# Altium schematic sheet 1.2.0_XBee_module.SchDoc
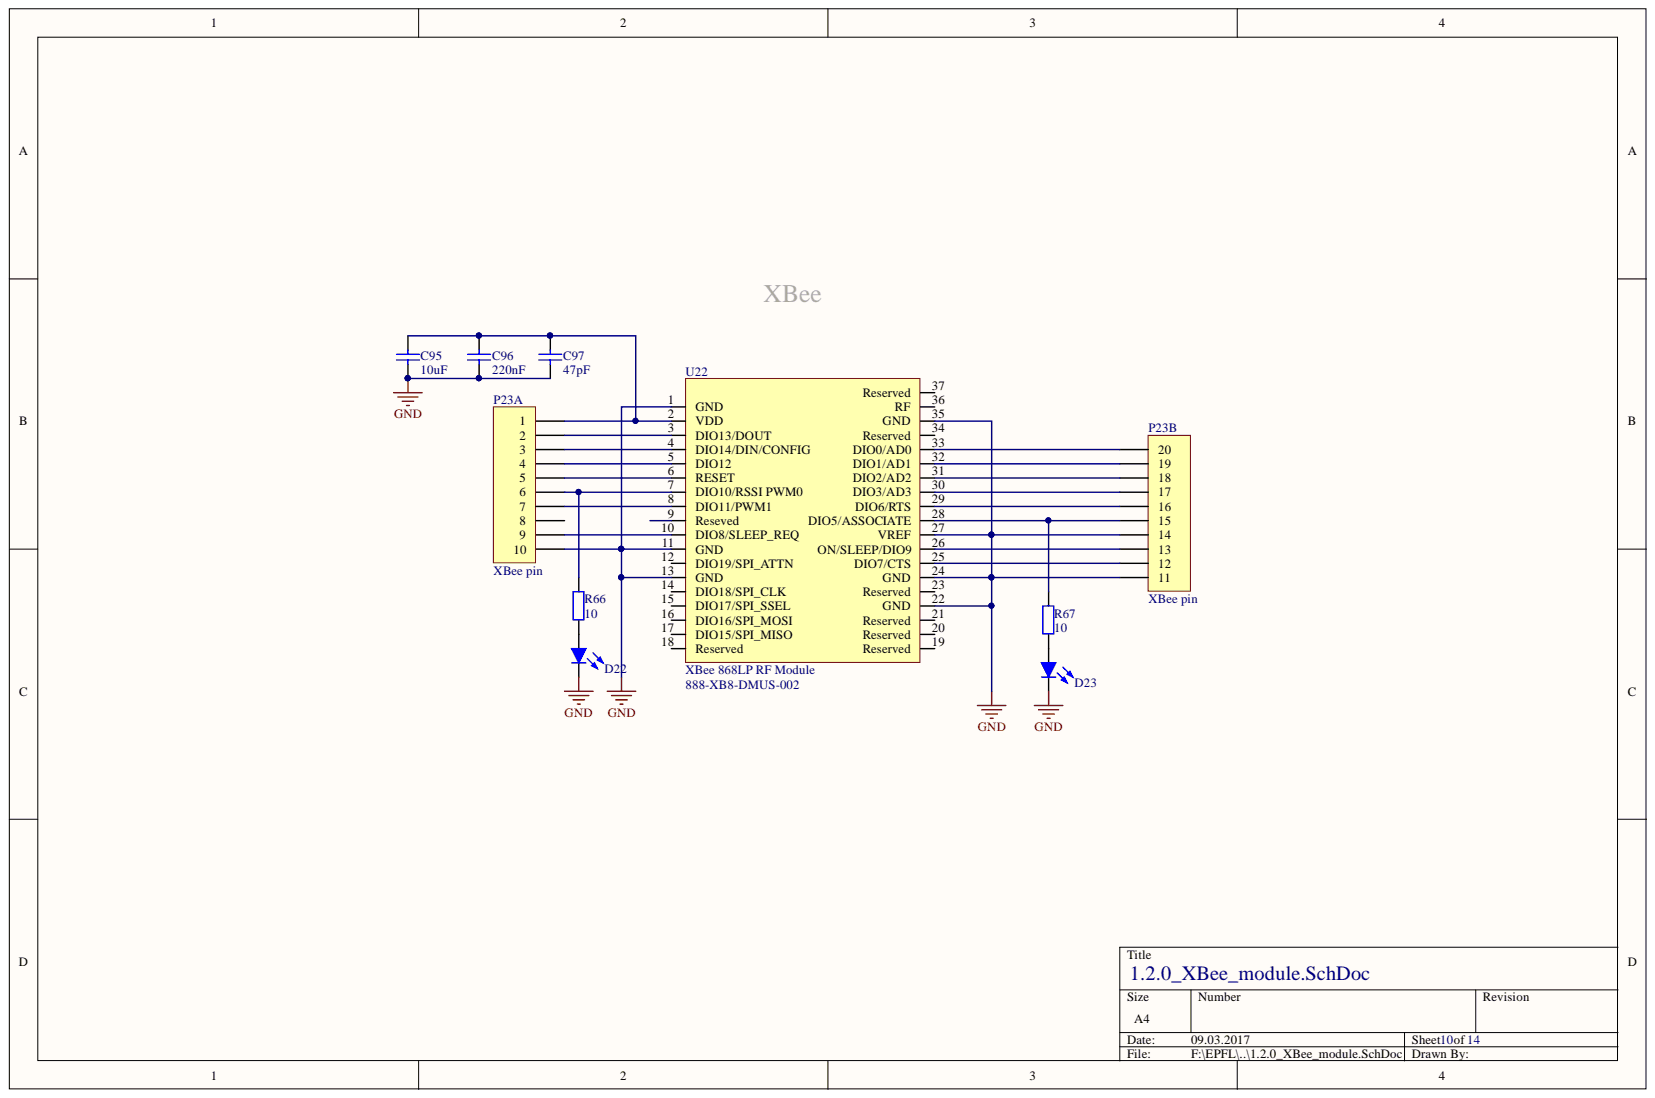
[diagram p0: 1.2.0_XBee_module.SchDoc]

## Parts
C95  10uF  [CAP0805W]
C96  220nF  [CAP0603R]
C97  47pF  [CAP0603R]
D22  LED  [WL-SMCW]  (value not drawn)
D23  LED  [WL-SMCW]  (value not drawn)
P23  XBee pin  [XBEE PIN]  (parts A,B)
R66  10  [0603]
R67  10  [0603]
U22  XBee 868LP RF Module  Supplier Part Number 1: 888-XB8-DMUS-002  [XBee 868LP RF Module]

## Nets
GND: C95.1 C96.1 C97.1 D22.C D23.C P23.10 P23.11 P23.14 U22.1(GND) U22.11(GND) U22.13(GND) U22.22(GND) U22.24(GND) U22.27(VREF) U22.35(GND)
NetC95_2: C95.2 C96.2 C97.2 P23.1 U22.2(VDD)
NetD22_A: D22.A R66.1
NetD23_A: D23.A R67.1
NetP23_2: P23.2 U22.3(DIO13/DOUT)
NetP23_3: P23.3 U22.4(DIO14/DIN/CONFIG)
NetP23_4: P23.4 U22.5(DIO12)
NetP23_5: P23.5 U22.6(RESET)
NetP23_6: P23.6 R66.2 U22.7(DIO10/RSSI_PWM0)
NetP23_7: P23.7 U22.8(DIO11/PWM1)
NetP23_9: P23.9 U22.10(DIO8/SLEEP_REQ)
NetP23_12: P23.12 U22.25(DIO7/CTS)
NetP23_13: P23.13 U22.26(ON/SLEEP/DIO9)
NetP23_15: P23.15 R67.2 U22.28(DIO5/ASSOCIATE)
NetP23_16: P23.16 U22.29(DIO6/RTS)
NetP23_17: P23.17 U22.30(DIO3/AD3)
NetP23_18: P23.18 U22.31(DIO2/AD2)
NetP23_19: P23.19 U22.32(DIO1/AD1)
NetP23_20: P23.20 U22.33(DIO0/AD0)

Not connected: P23 8; U22 9(Reseved) 12(DIO19/SPI_ATTN) 14(DIO18/SPI_CLK) 15(DIO17/SPI_SSEL) 16(DIO16/SPI_MOSI) 17(DIO15/SPI_MISO) 18(Reserved) 19(Reserved) 20(Reserved) 21(Reserved) 23(Reserved) 34(Reserved) 36 37(Reserved)

## Library data of the parts
C95 = C_10uF_16v: Capacitor; Component Kind: Standard; Supplier 1: Mouser; Supplier Part Number 1: 81-GRT21BC81C106ME1L; Library Name: Rocket_library.SchLib
C96 = C_220nF: Capacitor; Component Kind: Standard; Signal Integrity: Cap; Simulation: CAP; Supplier 1: Mouser; Supplier Part Number 1: 81-GCG188R91H224KA1D; Library Name: Rocket_library.SchLib
C97 = C_47pF_25V: Capacitor; Component Kind: Standard; Supplier 1: Mouser; Supplier Part Number 1: 77-VJ0603A470JXXPBC; Library Name: Rocket_library.SchLib
D22 D23 = LED_SMD_GREEN_0603: Standard LEDs - SMD WL-SMCW Waterclr 0603 Green; Component Kind: Standard; Supplier 1: Mouser; Supplier Part Number 1: 710-150060GS75000; Library Name: Rocket_library.SchLib
R66 R67 = R_0603_025W_10Ohm: 0.25W 10Ohm; Component Kind: Standard; Supplier 1: Mouser; Supplier Part Number 1: 667-ERJ-PA3J100V; Library Name: Rocket_library.SchLib
P23 = XBee pin: Component Kind: Standard; Description: XBee pin; Library Name: Rocket_library.SchLib
U22 = XBee 868LP RF Module: Component Kind: Standard; Description: XBee 868LP RF Module; Supplier 1: Mouser; Library Name: Rocket_library.SchLib
